# Altium schematic sheet laser_power_io.SchDoc
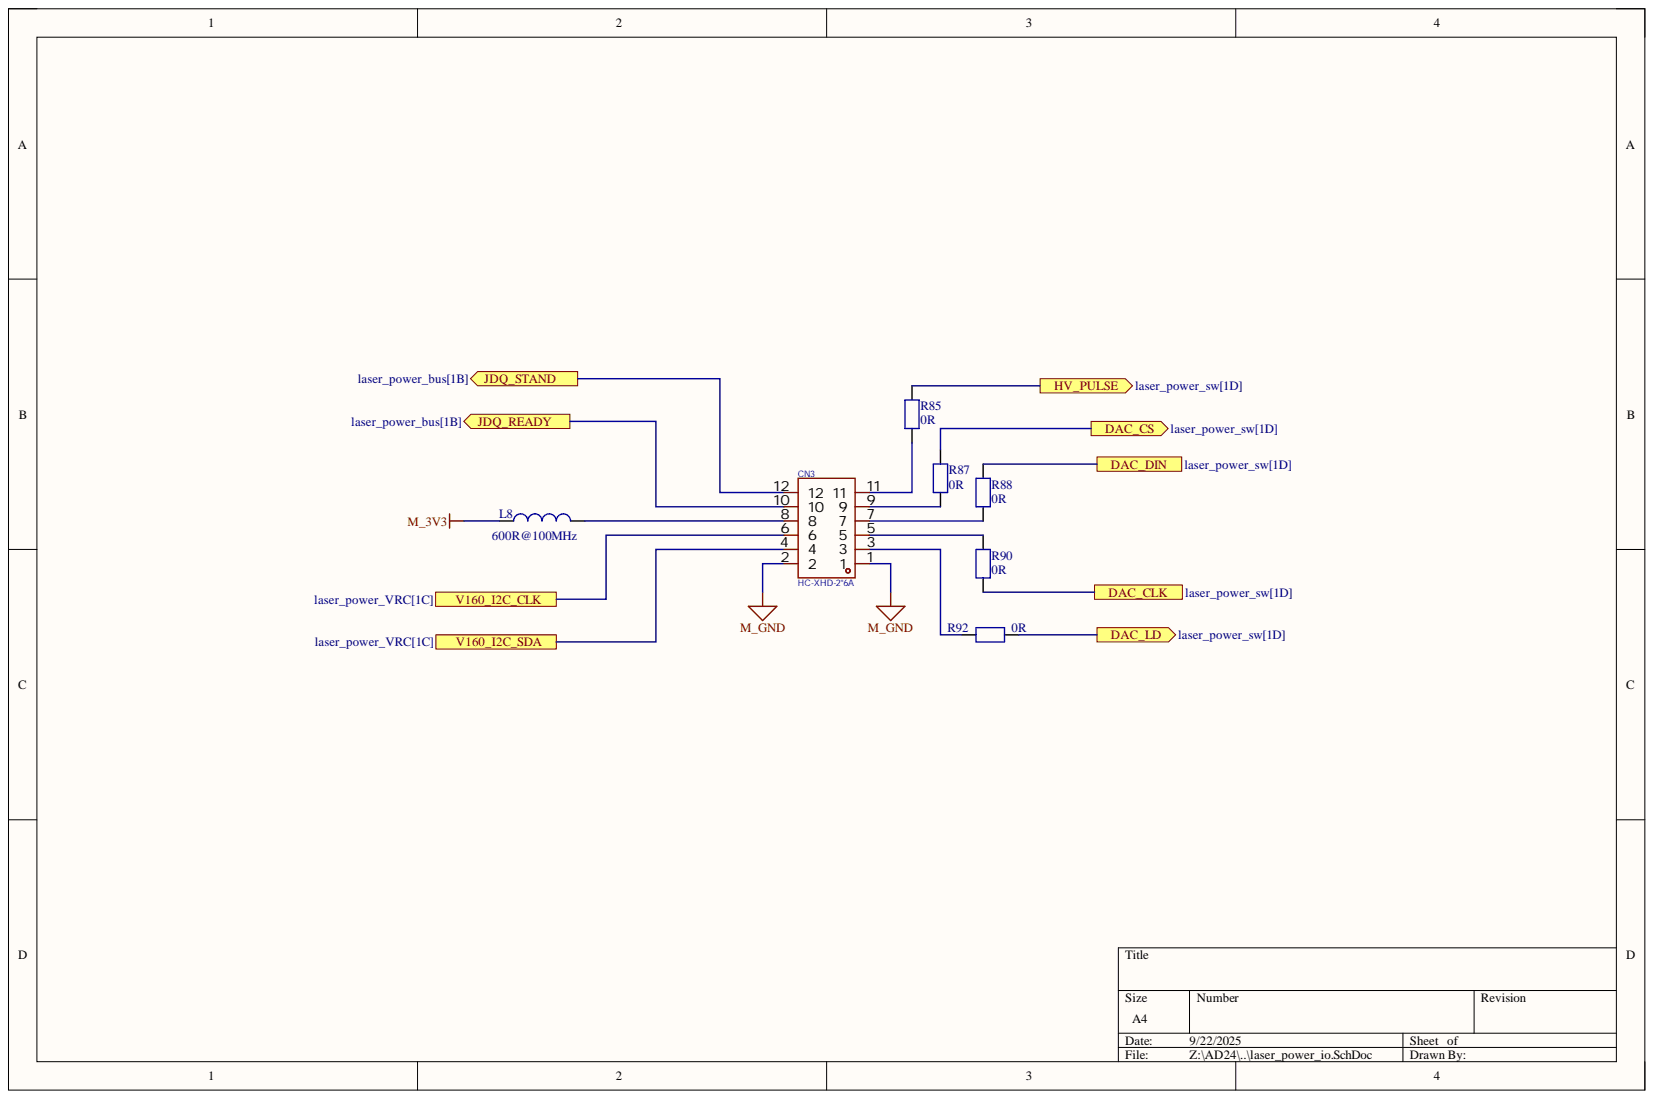
[diagram p0: laser_power_io.SchDoc]

## Parts
CN3  HC-XHD-2*6A  [CONN-TH_12P-P2.50_HCTL_HC-XHD-2X6A]
L8  600R@100MHz  [L0603]
R85  0R  [R0603]
R87  0R  [R0603]
R88  0R  [R0603]
R90  0R  [R0603]
R92  0R  [R0603]

## Ports
DAC_CLK, DAC_CS, DAC_DIN, DAC_LD, HV_PULSE, JDQ_READY, JDQ_STAND, V160_I2C_CLK, V160_I2C_SDA

## Nets
M_3V3: L8.1
M_GND: CN3.1 CN3.2
DAC_CLK: R90.2 ; port DAC_CLK
DAC_CS: R87.2 ; port DAC_CS
DAC_DIN: R88.2 ; port DAC_DIN
DAC_LD: R92.2 ; port DAC_LD
HV_PULSE: R85.2 ; port HV_PULSE
JDQ_READY: CN3.10 ; port JDQ_READY
JDQ_STAND: CN3.12 ; port JDQ_STAND
V160_I2C_CLK: CN3.6 ; port V160_I2C_CLK
V160_I2C_SDA: CN3.4 ; port V160_I2C_SDA
NetCN3_3: CN3.3 R92.1
NetCN3_5: CN3.5 R90.1
NetCN3_7: CN3.7 R88.1
NetCN3_8: CN3.8 L8.2
NetCN3_9: CN3.9 R87.1
NetCN3_11: CN3.11 R85.1

## Library data of the parts
CN3 = HC-XHD-2*6A: 插针结构2x6P，间距2.5mm，安装方式直插，参考系列DF1B; Manufacturer: HCTL(华灿天禄); Add into BOM: yes; Current Rating: 3A; Number of PINs Per Row: 6; Pins Structure: 2x6P; Pitch: 2.5mm; Plastic Material: PA66; Reference Series: DF1B; Voltage Rating: 250V; X-Length of Bottom Edge on Board (Spacing Line): 17.5mm; Y-Width of Bottom Edge on Board: 8.6mm; Z-Height of the Board: 9.5mm; Color: -; Component Kind: Standard; Contact Material: 黄铜; Contact Plating: 锡; Convert to PCB: yes; Datasheet: https://item.szlcsc.com/datasheet/HC-XHD-2*6A/3039334.html; Device: HC-XHD-2*6A; Flame Retardant Rating: -; JLCPCB Part Class: Extended Part; LCSC Part Name: 2x6P 间距:2.5mm 直插 系列:DF1B; Library Name: HiPin_Library_AD.IntLib; Manufacturer Part: HC-XHD-2*6A; Mounting Type: 直插; Number of Pins: 12P; Number of Rows: 2; Operating Temperature: -25℃~+85℃; PCB3D: CONN-TH_12P-P2.50_HCTL_HC-XHD-2X6A; Row Spacing: 2.5mm; Supplementary Features: -; Supplier: LCSC; Supplier Footprint: 插件,P=2.5mm; Supplier Part: C2845653; Symbol: HC-XHD-2*6A
L8 = MCD-00047: +/-25%, 350mA, 500mohm DC; Manufacturer: TAI-TECH; Manufacturer_Part_Number: FCM1608KF-601T03; Part_Type: Inductor; Database Table Name: MCD_PARTS$; Provided_By: SUBCO; Component Kind: Standard; ComponentLink1Description: Datasheet; ComponentLink1URL: http://tunmcdsvn.tun.st.com/Component_Datasheet_Library/Trunk/Inductors/TaiTech_Bead_FCM1608_series; ECCN_EU: n/a; ECCN_US: n/a; Internal_Part_Number: MCD-00047; Library Name: MCD_PARTS_database.DbLib; Status: Released; Value: 600R@100MHz
R85 R87 R88 R90 R92 = RES: 1%; Value: 10K; Component Kind: Standard; Library Name: HiPin_Library_AD.IntLib
